# Altium schematic sheet InfoDisplayPower.SchDoc
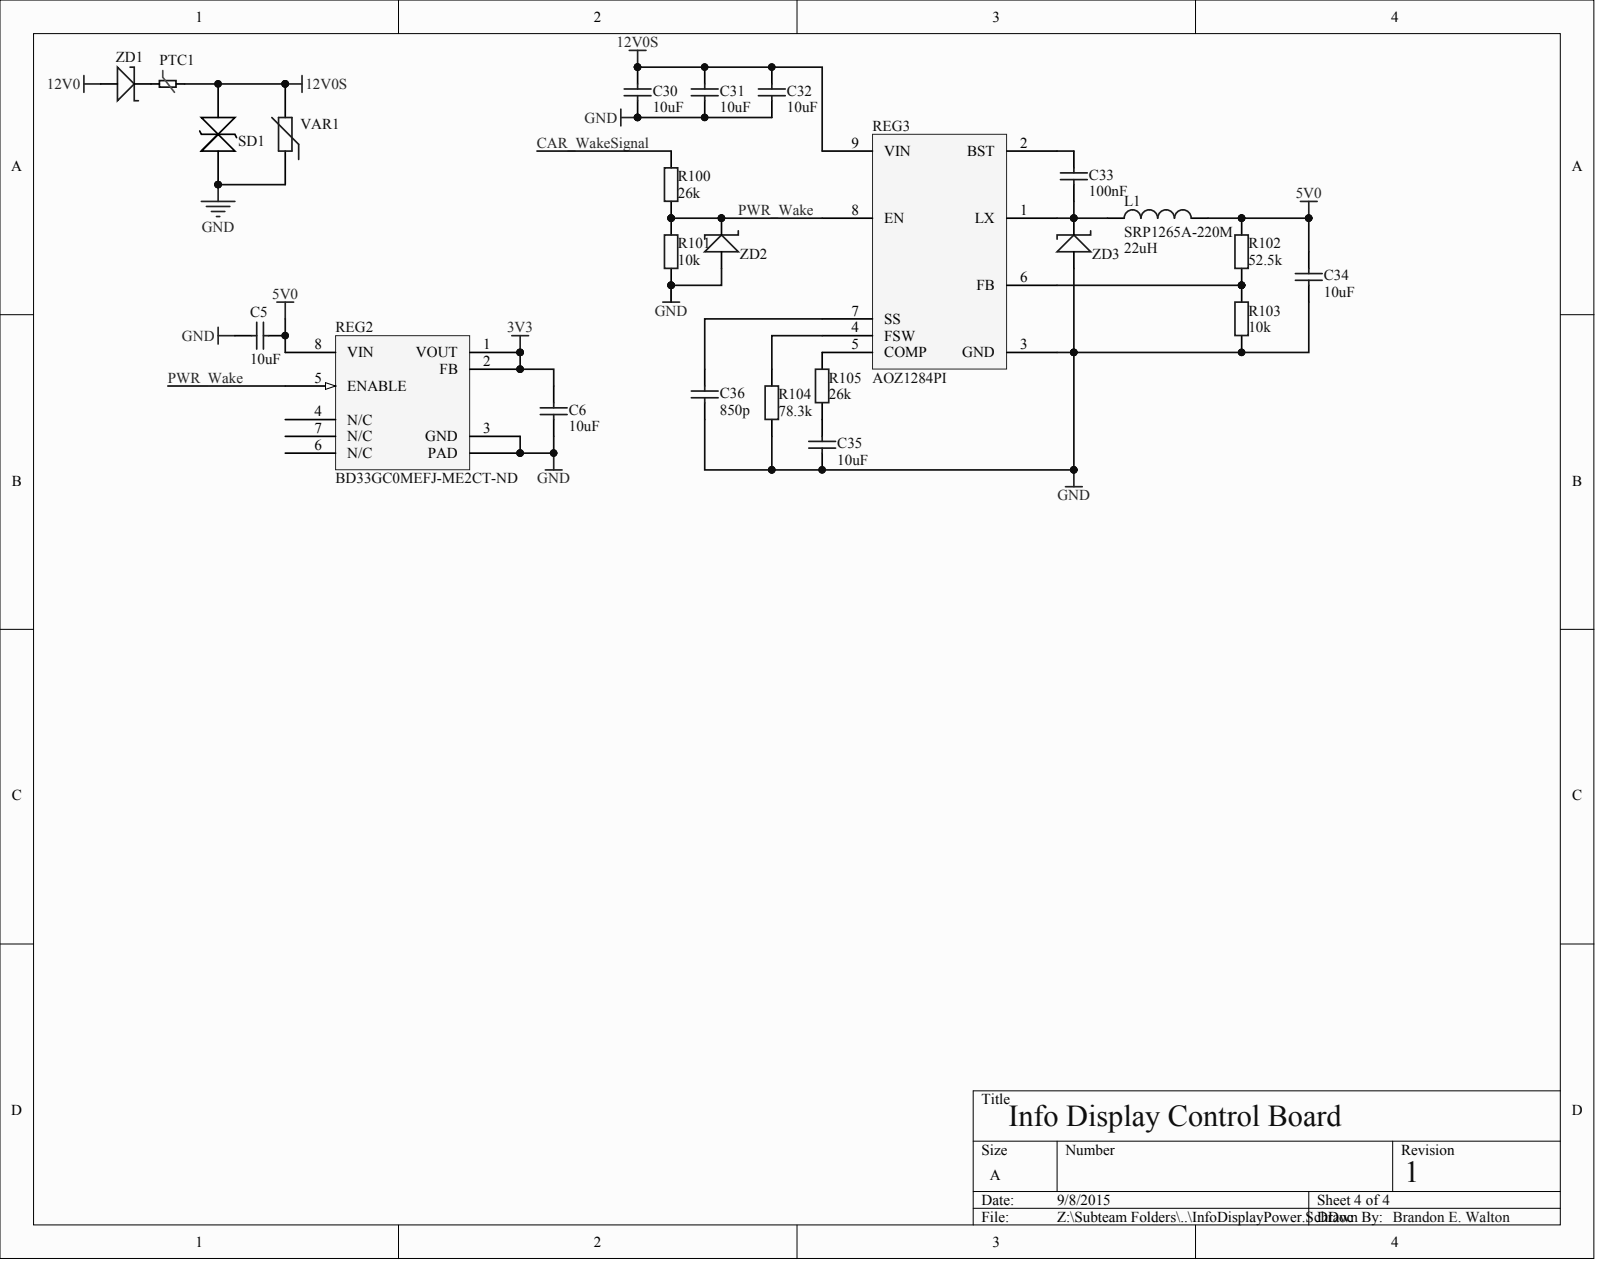
[diagram p0: InfoDisplayPower.SchDoc]

## Parts
C5  value 10uF  (hidden comment: CGA5L1X7R1E106K160AC)
C6  value 10uF  (hidden comment: CGA5L1X7R1E106K160AC)
C30  value 10uF  (hidden comment: CGA5L1X7R1E106K160AC)
C31  value 10uF  (hidden comment: CGA5L1X7R1E106K160AC)
C32  value 10uF  (hidden comment: CGA5L1X7R1E106K160AC)
C33  value 100nF  (hidden comment: GRM155R71C104KA88D)
C34  value 10uF  (hidden comment: CGA5L1X7R1E106K160AC)
C35  value 10uF  (hidden comment: CGA5L1X7R1E106K160AC)
C36  value 850p  (hidden comment: GRM033R71E821KA01D)
L1  SRP1265A-220M  value 22uH
PTC1  0ZCF0200AF2C  (value not drawn)
R100  value 26k  (hidden comment: MCT06030C2702FP500)
R101  value 10k  (hidden comment: RC0603JR-0710KL)
R102  value 52.5k  (hidden comment: RC0603FR-0752K3L)
R103  value 10k  (hidden comment: RC0603JR-0710KL)
R104  value 78.3k  (hidden comment: RC0402FR-0778K7L)
R105  value 26k  (hidden comment: MCT06030C2702FP500)
REG2  BD33GC0MEFJ-ME2CT-ND
REG3  AOZ1284PI
SD1  UCLAMP1211P.TCT  (value not drawn)
VAR1  V10P14AUTO  (value not drawn)
ZD1  DB2430100L  (value not drawn)
ZD2  MM3Z3V3ST1G  (value not drawn)
ZD3  DB2431200L  (value not drawn)

## Nets
3V3: C6.1 REG2.1(VOUT) REG2.2(FB)
5V0: C5.2 C34.1 L1.2 R102.2 REG2.8(VIN)
12V0: ZD1.A
12V0S: C30.1 C31.1 C32.1 PTC1.2 REG3.9(VIN) SD1.2 VAR1.2
GND: C5.1 C6.2 C30.2 C31.2 C32.2 C34.2 C35.2 C36.2 R101.1 R103.1 R104.1 REG2.3(GND) REG2.9(PAD) REG3.3(GND) SD1.1 VAR1.1 ZD2.A ZD3.A
CAR_WAKESIGNAL: R100.2
PWR_WAKE: R100.1 R101.2 REG2.5(ENABLE) REG3.8(EN) ZD2.K
NetC33_1: C33.1 REG3.2(BST)
NetC33_2: C33.2 L1.1 REG3.1(LX) ZD3.K
NetC35_1: C35.1 R105.1
NetC36_1: C36.1 REG3.7(SS)
NetPTC1_1: PTC1.1 ZD1.K
NetR102_1: R102.1 R103.2 REG3.6(FB)
NetR104_2: R104.2 REG3.4(FSW)
NetR105_2: R105.2 REG3.5(COMP)

Not connected: REG2 4(N/C) 6(N/C) 7(N/C)

## Library data of the parts
C36 = (no symbol name): PartNumber: CGA5L1X7R1E106K160AC; Published: 8-Jun-2000; LatestRevisionDate: 17-Jul-2002; LatestRevisionNote: Re-released for DXP Platform.; PackageReference: RAD-0.3; PackageDescription: Radial Cap, Thru-Hole; 2 Leads; 0.3 in Pin Spacing; Digikey: 490-3183-1-ND; Publisher: Altium Limited
L1 = (no symbol name): Code_IEC: 1005; Value: 22uH; Published: 8-Jun-2000; LatestRevisionDate: 29-May-2009; LatestRevisionNote: IPC-7351 Footprint Added.; PackageReference: 0402-A; PackageDescription: Chip Inductor; Code_JEITA: 0402; Digikey: SRP1265A-220MCT-ND; Partnumber: SRP1265A-220M; Publisher: Altium Limited
R100-R105 = (no symbol name): Partnumber: RC0603FR-07422KL; Published: 8-Jun-2000; LatestRevisionDate: 17-Jul-2002; LatestRevisionNote: Re-released for DXP Platform.; PackageReference: AXIAL-0.4; PackageDescription: Axial Device, Thru-Hole; 2 Leads; 0.4 in Pin Spacing; Publisher: Altium Limited
  R100 R105: Digikey: MCT0603-27.0K-CFCT-ND
  R101 R103: Digikey: 311-10KGRCT-ND
  R102: Digikey: 311-52.3KHRCT-ND
  R104: Digikey: 311-78.7KLRCT-ND
C5 C6 C30-C35 PTC1 REG2 REG3 SD1 VAR1 ZD1-ZD3 = (no symbol name)
  C5 C6 C30-C32 C34 C35: Published: 8-Jun-2000; LatestRevisionDate: 17-Jul-2002; LatestRevisionNote: Re-released for DXP Platform.; PackageReference: RAD-0.3; PackageDescription: Radial Cap, Thru-Hole; 2 Leads; 0.3 in Pin Spacing; Digikey: 445-6990-1-ND; PartNumber: CGA5L1X7R1E106K160AC
  C33: Published: 8-Jun-2000; LatestRevisionDate: 17-Jul-2002; LatestRevisionNote: Re-released for DXP Platform.; PackageReference: RAD-0.3; PackageDescription: Radial Cap, Thru-Hole; 2 Leads; 0.3 in Pin Spacing; Digikey: 490-3261-1-ND; PartNumber: GRM155R71C104KA88D
  PTC1: Digikey: 507-1749-1-ND; Manufacturer PartNo: 0ZCF0200AF2C
  REG2: Digikey: BD33GC0MEFJ-ME2CT-ND; Partnumber: BD33GC0MEFJ-ME2
  REG3: Manufacturer PartNo: AOZ1284PI; Digikey: 785-1689-1-ND
  SD1: Digikey: UCLAMP1211PCT-ND; PartNumber: UCLAMP1211P.TCT
  VAR1: Published: 24-Mar-1999; LatestRevisionDate: 29-May-2009; LatestRevisionNote: IPC-7351 Footprint Added.; PackageVersion: Mar-2003; PackageReference: 6-0805; PackageDescription: Chip Resistor 0805 Case Size; Code_IPC: RESC2012; Digikey: F6413-ND; Partnumber: V10P14AUTO
  ZD1: Digikey: DB2430100LCT-ND; Partnumber: DB2430100L
  ZD2: Digikey: MM3Z3V3ST1GOSCT-ND; Partnumber: MM3Z3V3ST1G
  ZD3: Digikey: DB2431200LCT-ND; Partnumber: DB2431200L
  C5 C6 C30-C35 VAR1: Publisher: Altium Limited
